# Altium schematic sheet main.SchDoc(main)
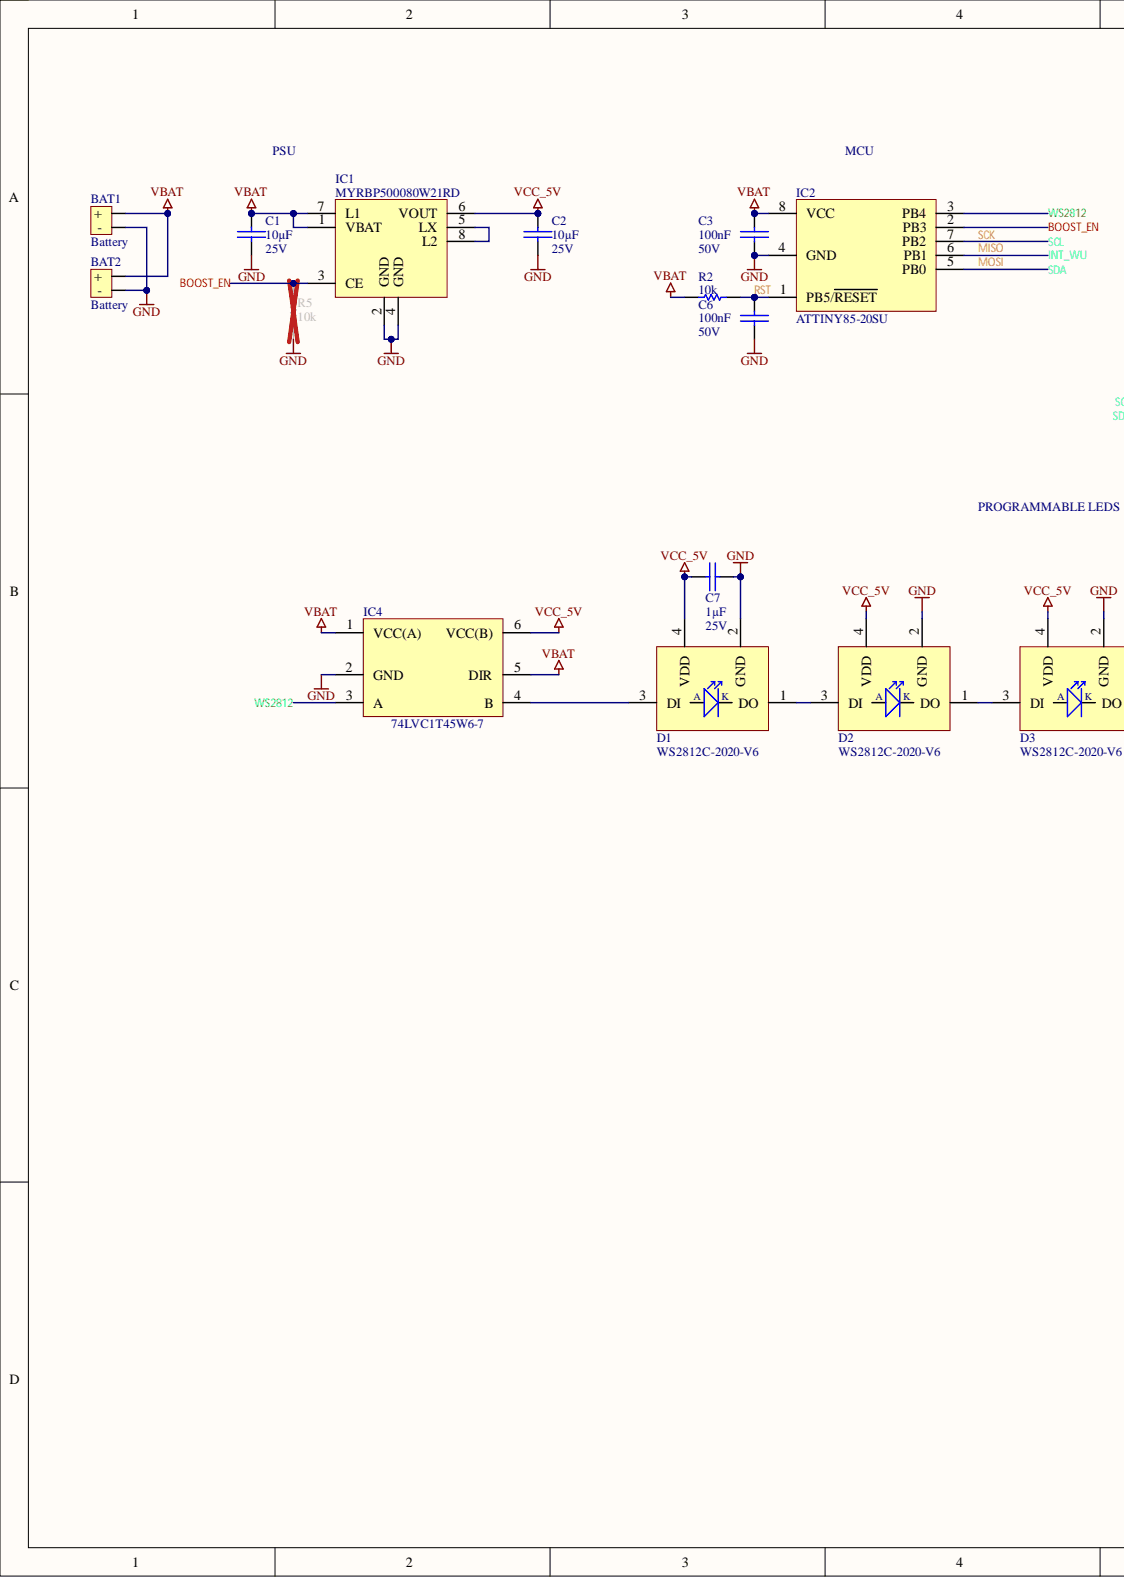
[diagram p0: main.SchDoc, left]
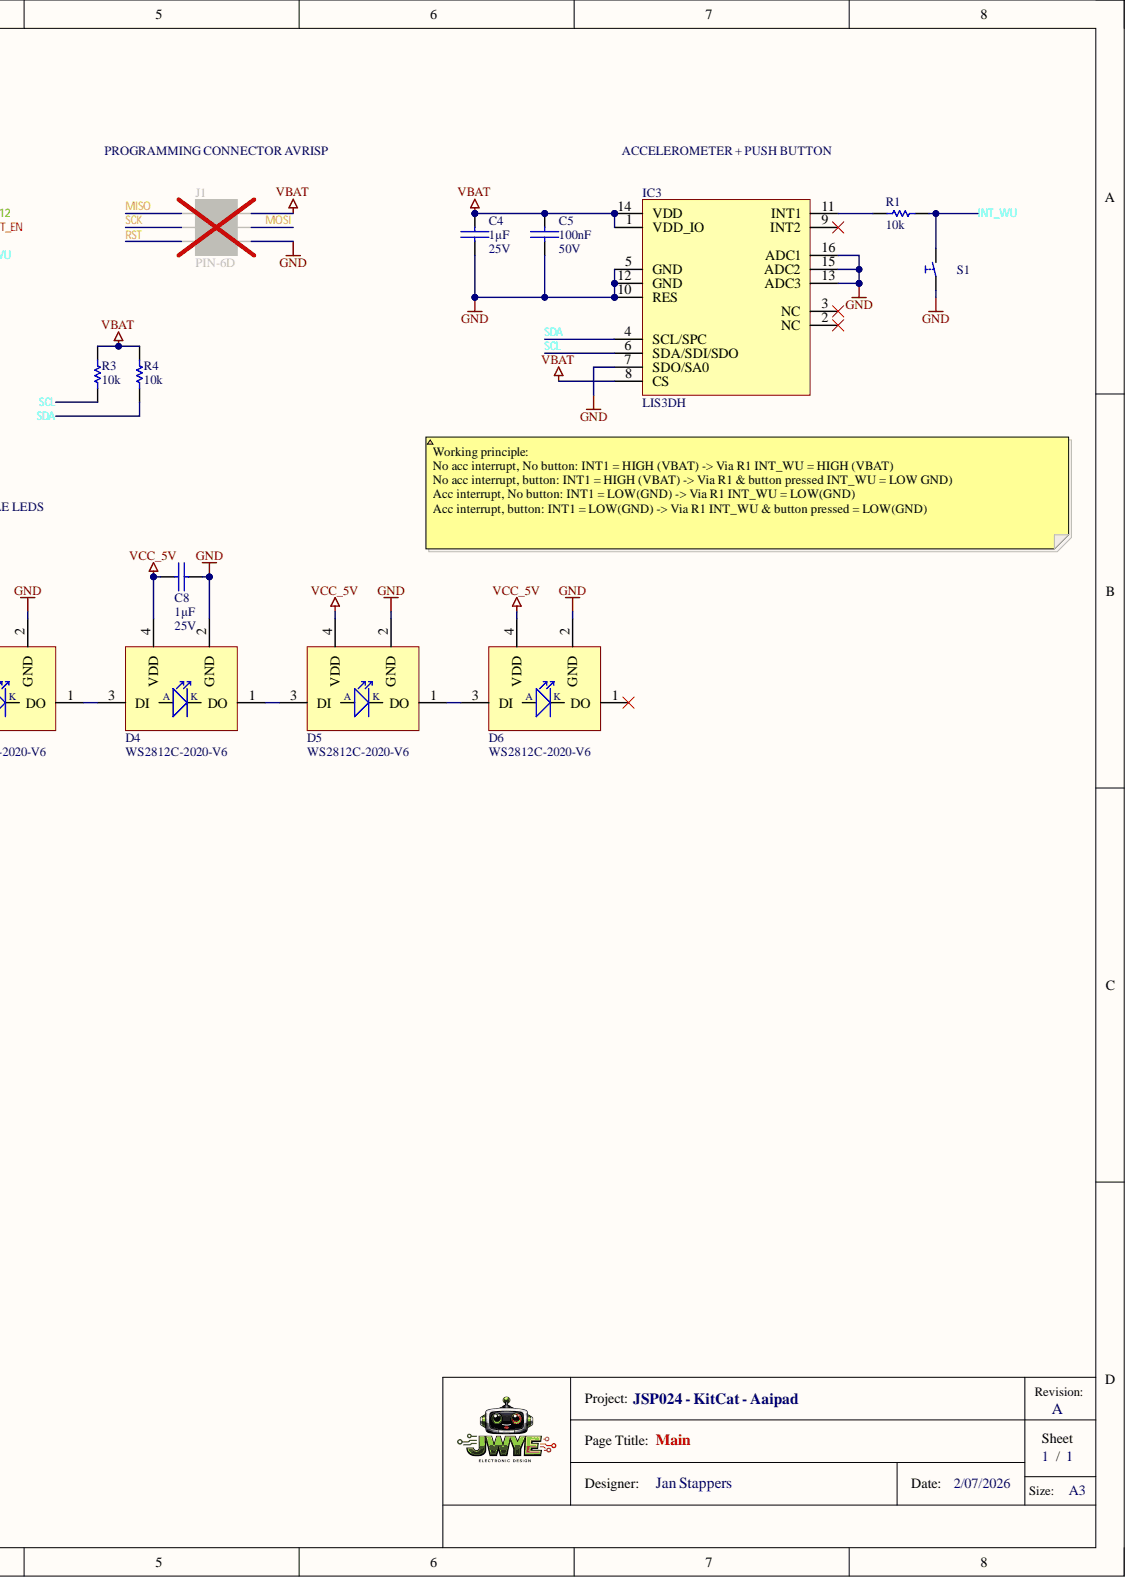
[diagram p1: main.SchDoc, right]

## Parts
BAT1  Battery  [CR2032-A1_HE]
BAT2  Battery  [CR2032-A1_HE]
C1  value 10µF  voltage: 25V  [C0603]  (hidden comment: cap_non_pol)
C2  value 10µF  voltage: 25V  [C0603]  (hidden comment: cap_non_pol)
C3  value 100nF  [C0402]  (hidden comment: cap_non_pol)
C4  value 1µF  voltage: 25V  [C0603]  (hidden comment: cap_non_pol)
C5  value 100nF  voltage: 50V  [C0402]  (hidden comment: cap_non_pol)
C6  value 100nF  voltage: 50V  [C0402]  (hidden comment: cap_non_pol)
C7  value 1µF  [C0603]  (hidden comment: cap_non_pol)
C8  value 1µF  [C0603]  (hidden comment: cap_non_pol)
D1  WS2812C-2020-V6  [WS2812C-2020-V6]
D2  WS2812C-2020-V6  [WS2812C-2020-V6]
D3  WS2812C-2020-V6  [WS2812C-2020-V6]
D4  WS2812C-2020-V6  [WS2812C-2020-V6]
D5  WS2812C-2020-V6  [WS2812C-2020-V6]
D6  WS2812C-2020-V6  [WS2812C-2020-V6]
IC1  MYRBP500080W21RD  [MYRBP500080W21RD]
IC2  ATTINY85-20SU  [SOIC-8]
IC3  LIS3DH  [LGA-16]
IC4  74LVC1T45W6-7  [SOT26]
J1  PIN-6D  [2057-BHR-06-VUA]
R1  value 10k  [R0402]  (hidden comment: resistor)
R2  value 10k  [R0402]  (hidden comment: resistor)
R3  value 10k  [R0402]  (hidden comment: resistor)
R4  value 10k  [R0402]  (hidden comment: resistor)
R5  value 10k  [R0402]  (hidden comment: resistor)
S1  push-button  [TC-0522C-1.6-160G]  (value not drawn)

## Nets
GND: BAT1.- BAT2.- C1.1 C2.1 C3.2 C4.1 C5.1 C6.2 C7.2 C8.2 D1.2(GND) D2.2(GND) D3.2(GND) D4.2(GND) D5.2(GND) D6.2(GND) IC1.2(GND) IC1.4(GND) IC2.4(GND) IC3.5(GND) IC3.7(SDO/SA0) IC3.10(RES) IC3.12(GND) IC3.13(ADC3) IC3.15(ADC2) IC3.16(ADC1) IC4.2(GND) J1.6 R5.1 S1.1
VBAT: BAT1.+ BAT2.+ C1.2 C3.1 C4.2 C5.2 IC1.1(VBAT) IC1.7(L1) IC2.8(VCC) IC3.1(VDD_IO) IC3.8(CS) IC3.14(VDD) IC4.1(VCC(A)) IC4.5(DIR) J1.2 R2.1 R3.2 R4.2
VCC_5V: C2.2 C7.1 C8.1 D1.4(VDD) D2.4(VDD) D3.4(VDD) D4.4(VDD) D5.4(VDD) D6.4(VDD) IC1.6(VOUT) IC4.6(VCC(B))
BOOST_EN: IC1.3(CE) IC2.2(PB3) R5.2
INT_WU: IC2.6(PB1) J1.1 R1.2 S1.2
MOSI: IC2.5(PB0) IC3.4(SCL/SPC) J1.4 R4.1
RST: C6.1 IC2.1(PB5/RESET) J1.5 R2.2
SCK: IC2.7(PB2) IC3.6(SDA/SDI/SDO) J1.3 R3.1
WS2812: IC2.3(PB4) IC4.3(A)
NetD1_1: D1.1(DO) D2.3(DI)
NetD1_3: D1.3(DI) IC4.4(B)
NetD2_1: D2.1(DO) D3.3(DI)
NetD3_1: D3.1(DO) D4.3(DI)
NetD4_1: D4.1(DO) D5.3(DI)
NetD5_1: D5.1(DO) D6.3(DI)
NetIC1_5: IC1.5(LX) IC1.8(L2)
NetIC3_11: IC3.11(INT1) R1.1

Not connected: D6 1(DO); IC3 2(NC) 3(NC) 9(INT2)

## Library data of the parts
BAT1 BAT2 = 72: CR2032 Battery base Gold Phosphor bronze -25℃~+85℃ SMD Blade Type Power Connector Assemblies RoHS; manufacturer: HanElectricity; manufacturer_ref: CR2032-A1; Database Table Name: connector$; nbr_positions_total: 2; Component Kind: Standard; id: 72; package: CR2032; price: 0,1528; status: active; supplier_1: lcsc; supplier_1_partnumber: C48601333; Library Name: bond-altium-excell.DbLib
C1 C2 = 1: CAP CER 10UF 25V X5R 10% SMD; manufacturer: Murata Electronics; manufacturer_ref: GRM188R61E106KA73D; Database Table Name: capacitor_ceramic$; Component Kind: Standard; dielectric: X5R; id: 1; package: 0603; price: 0,06969; status: active; supplier_1: digikey; supplier_1_partnumber: 490-18214-1-ND; tolerance: 10%; Library Name: bond-altium-excell.DbLib
C3 C5 C6 = 0: CAP CER 0.1UF 50V X5R 0402; manufacturer: Murata Electronics; manufacturer_ref: 490-16356-1-ND; Database Table Name: capacitor_ceramic$; tolerance: 5%; Component Kind: Standard; dielectric: X5R; id: 0; package: 0402; price: 0,00933; status: non stock; supplier_1: digikey; supplier_1_partnumber: 490-16356-1-ND; Library Name: bond-altium-excell.DbLib
  C3: voltage: 50V
C4 C7 C8 = 34: CAP CER 1UF 25V X5R 0603; manufacturer: YAGEO; manufacturer_ref: CC0603KRX5R8BB105; Database Table Name: capacitor_ceramic$; tolerance: 10%; Component Kind: Standard; dielectric: X5R; id: 34; package: 0603; price: 0,01837; status: active; supplier_1: digikey; supplier_1_partnumber: 311-1445-1-ND; Library Name: bond-altium-excell.DbLib
  C7 C8: voltage: 25V
D1-D6 = 114: SMD,2x2mm RGB LEDs(Built-in IC) ROHS; manufacturer: Worldsemi; Database Table Name: ic$; type: ADDRESSABLE LED; Component Kind: Standard; id: 114; manufacturer_ref: WS2812C-2020-V6; package: WS2812C-2020-V6; price: 0,0706; status: active; supplier_1: lcsc; supplier_1_partnumber: C55109522; Library Name: bond-altium-excell.DbLib
IC1 = 111: DC DC CONVERTER 5.0V NON-ISOL; Database Table Name: ic$; Component Kind: Standard; id: 111; manufacturer_ref: MYRBP500080W21RD; package: 8-PowerTDFN Module; price: 1,03; status: active; supplier_1: digikey; supplier_1_partnumber: 811-MYRBP500080W21RDCT-ND; type: Power Supply (Boost); Library Name: bond-altium-excell.DbLib
IC2 = 92: IC MCU 8BIT 8KB FLASH 8SOIC; manufacturer: Microchip Technology; Database Table Name: ic$; Component Kind: Standard; id: 92; manufacturer_ref: ATTINY85-20SU; package: 8-SOIC; price: 1,274; status: active; supplier_1: digikey; supplier_1_partnumber: ATTINY85-20SU-ND; type: MCU; Library Name: bond-altium-excell.DbLib
IC3 = 98: ACCEL 2-16G I2C/SPI 16LGA; manufacturer: STMicroelectronics; manufacturer_ref: LIS3DHTR; Database Table Name: ic$; Component Kind: Standard; id: 98; package: 16-LGA; status: active; supplier_1: digikey; supplier_1_partnumber: 497-10613-1-ND; type: Sensor (Accel.); Library Name: bond-altium-excell.DbLib
IC4 = 113: IC XLTR VL BIDIR SOT-26; manufacturer: Diodes Incorporated; Database Table Name: ic$; Component Kind: Standard; id: 113; manufacturer_ref: 74LVC1T45W6-7; package: SOT-26; status: active; supplier_1: digikey; supplier_1_partnumber: 74LVC1T45W6-7DICT-ND; type: Wired (Translator); Library Name: bond-altium-excell.DbLib
J1 = 63: CONN HEADER VERT 6POS 2.54MM; manufacturer: Adam Tech; Database Table Name: connector$; nbr_positions_total: 6; Component Kind: Standard; id: 63; manufacturer_ref: 2057-BHR-06-VUA; package: Pin-6DR; price: 0,192; status: active; supplier_1: digikey; supplier_1_partnumber: 2057-BHR-06-VUA-ND; Library Name: bond-altium-excell.DbLib
R1-R5 = 6: RES SMD 10K OHM 1% 1/10W 0402; manufacturer: Panasonic Electronic Components; manufacturer_ref: ERJ-2RKF1002X; Database Table Name: resistor_general$; Component Kind: Standard; id: 6; package: 0402; power: 1/10W; price: 0,00703; status: active; supplier_1: digikey; supplier_1_partnumber: P10.0KLCT-ND; tolerance: 1%; Library Name: bond-altium-excell.DbLib
S1 = 10: SWITCH TACTILE SPST 160gf SMD; manufacturer: HCTL; Database Table Name: switch$; Component Kind: Standard; id: 10; manufacturer_ref: TC-0522C-1.6-160G; package: TC-0522C-1.6-160G; price: 0,0111; status: active; supplier_1: lcsc; supplier_1_partnumber: C2845287; Library Name: bond-altium-excell.DbLib
